# Altium schematic sheet BQ25703A_Module.SchDoc(BQ25703A_Module)
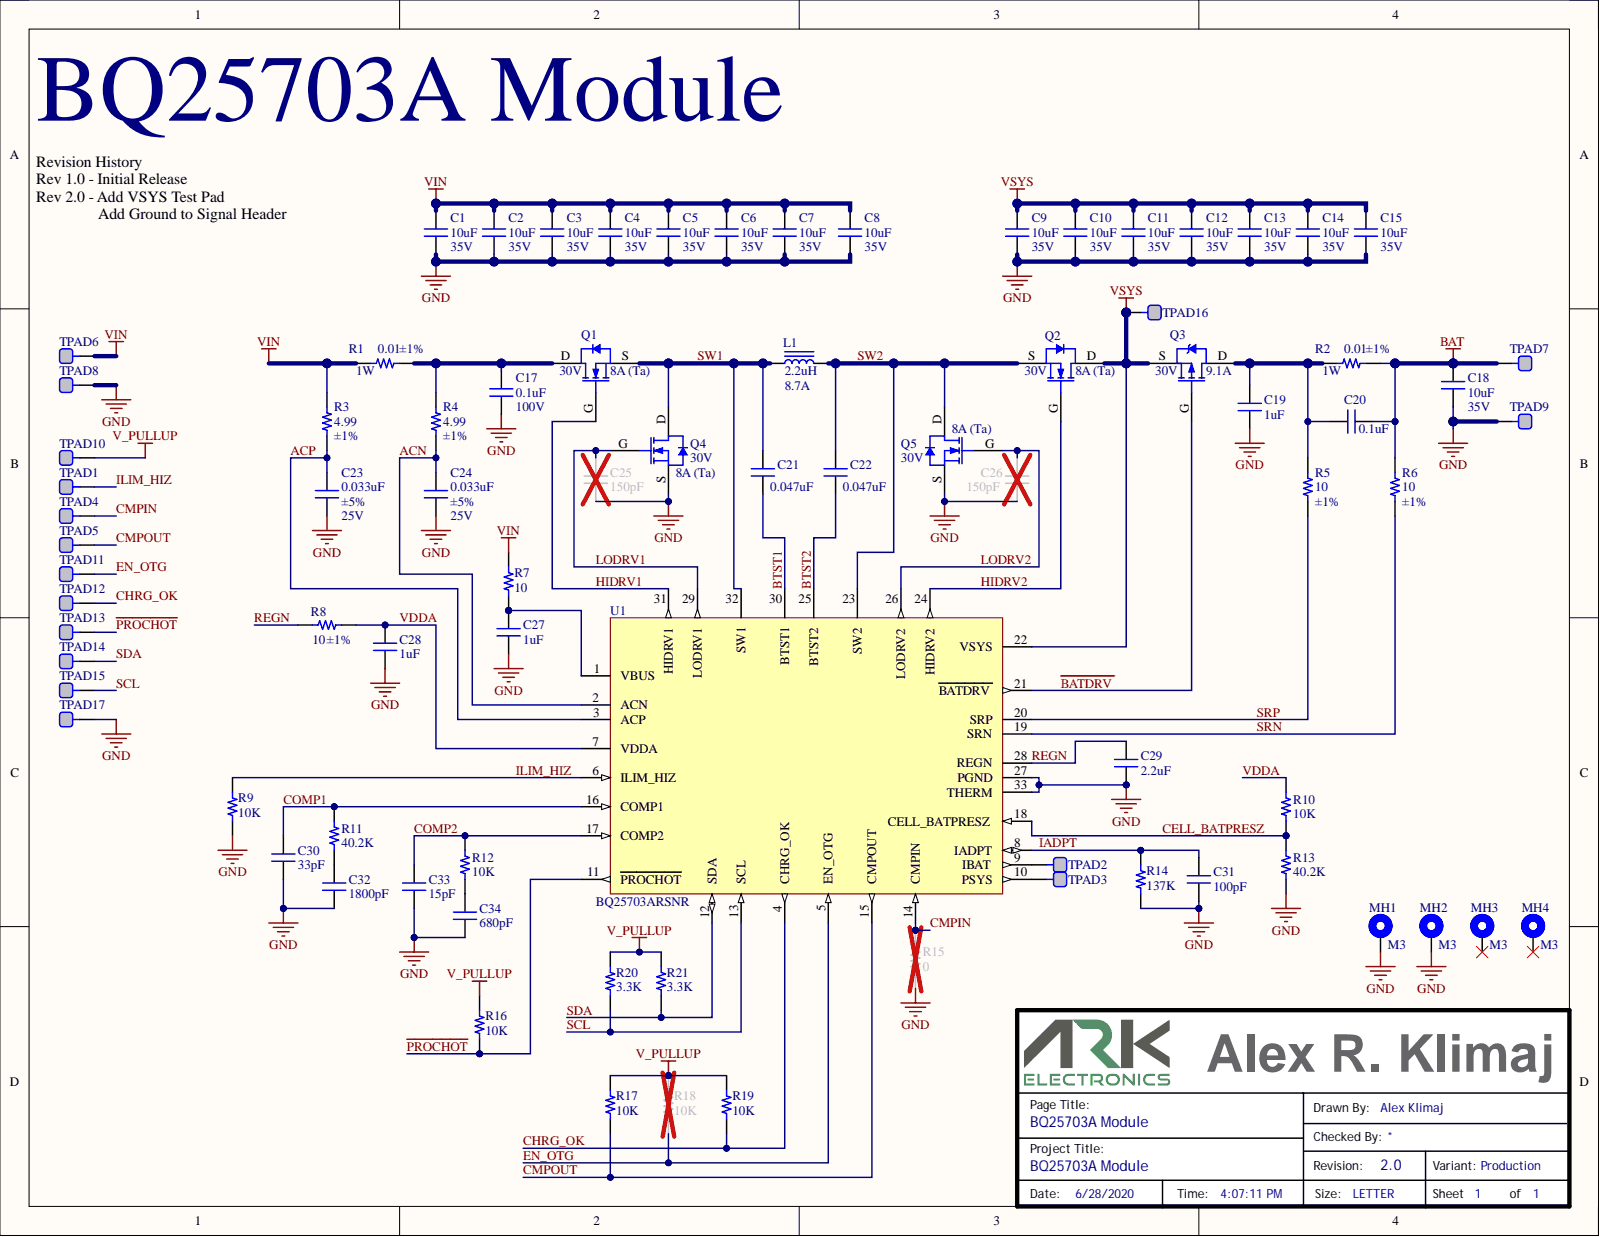
[diagram p0: BQ25703A_Module.SchDoc]

## Parts
C1  10uF  Voltage_Rating: 35V  [CAPC0805(2012)155_N]
C2  10uF  Voltage_Rating: 35V  [CAPC0805(2012)155_N]
C3  10uF  Voltage_Rating: 35V  [CAPC0805(2012)155_N]
C4  10uF  Voltage_Rating: 35V  [CAPC0805(2012)155_N]
C5  10uF  Voltage_Rating: 35V  [CAPC0805(2012)155_N]
C6  10uF  Voltage_Rating: 35V  [CAPC0805(2012)155_N]
C7  10uF  Voltage_Rating: 35V  [CAPC0805(2012)155_N]
C8  10uF  Voltage_Rating: 35V  [CAPC0805(2012)155_N]
C9  10uF  Voltage_Rating: 35V  [CAPC0805(2012)155_N]
C10  10uF  Voltage_Rating: 35V  [CAPC0805(2012)155_N]
C11  10uF  Voltage_Rating: 35V  [CAPC0805(2012)155_N]
C12  10uF  Voltage_Rating: 35V  [CAPC0805(2012)155_N]
C13  10uF  Voltage_Rating: 35V  [CAPC0805(2012)155_N]
C14  10uF  Voltage_Rating: 35V  [CAPC0805(2012)155_N]
C15  10uF  Voltage_Rating: 35V  [CAPC0805(2012)155_N]
C17  0.1uF  Voltage_Rating: 100V  [CAPC0805(2012)155_N]
C18  10uF  Voltage_Rating: 35V  [CAPC0805(2012)155_N]
C19  1uF  [CAPC0805(2012)155_N]
C20  0.1uF  [CAPC0805(2012)155_N]
C21  0.047uF  [CAPC0805(2012)155_N]
C22  0.047uF  [CAPC0805(2012)155_N]
C23  0.033uF  Tolerance: ±5%  Voltage_Rating: 25V  [CAPC0805(2012)155_N]
C24  0.033uF  Tolerance: ±5%  Voltage_Rating: 25V  [CAPC0805(2012)155_N]
C25  150pF  [CAPC0805(2012)155_N]
C26  150pF  [CAPC0805(2012)155_N]
C27  1uF  [CAPC0805(2012)155_N]
C28  1uF  [CAPC0805(2012)155_N]
C29  2.2uF  [CAPC0805(2012)155_N]
C30  33pF  [CAPC0805(2012)155_N]
C31  100pF  [CAPC0805(2012)155_N]
C32  1800pF  [CAPC0805(2012)155_N]
C33  15pF  [CAPC0805(2012)155_N]
C34  680pF  [CAPC0805(2012)155_N]
L1  2.2uH  Current_Rating: 8.7A  [DD1217AS-H-2R2N=P3]
MH1  M3  [Mounting Hole M3]
MH2  M3  [Mounting Hole M3]
MH3  M3  [Mounting Hole M3]
MH4  M3  [Mounting Hole M3]
Q1  Current_Rating: 8A (Ta)  Voltage_Rating: 30V  [RQ3E080BNTB]  (hidden comment: RQ3E080BNTB)
Q2  Current_Rating: 8A (Ta)  Voltage_Rating: 30V  [RQ3E080BNTB]  (hidden comment: RQ3E080BNTB)
Q3  Current_Rating: 9.1A  Voltage_Rating: 30V  [SOP-8 PMOS Micro Commercial]  (hidden comment: MCQ4435-TP)
Q4  Current_Rating: 8A (Ta)  Voltage_Rating: 30V  [RQ3E080BNTB]  (hidden comment: RQ3E080BNTB)
Q5  Current_Rating: 8A (Ta)  Voltage_Rating: 30V  [RQ3E080BNTB]  (hidden comment: RQ3E080BNTB)
R1  0.01  Power_Rating: 1W  [RES1206]
R2  0.01  Power_Rating: 1W  [RES1206]
R3  4.99  Tolerance: ±1%  [RESC0805(2012)_L]
R4  4.99  Tolerance: ±1%  [RESC0805(2012)_L]
R5  10  Tolerance: ±1%  [RESC0805(2012)_L]
R6  10  Tolerance: ±1%  [RESC0805(2012)_L]
R7  10  [RESC0805(2012)_L]
R8  10  Tolerance: ±1%  [RESC0805(2012)_L]
R9  10K  [RESC0805(2012)_L]
R10  10K  [RESC0805(2012)_L]
R11  40.2K  [RESC0805(2012)_L]
R12  10K  [RESC0805(2012)_L]
R13  40.2K  [RESC0805(2012)_L]
R14  137K  [RESC0805(2012)_L]
R15  0  [RESC0805(2012)_L]
R16  10K  [RESC0805(2012)_L]
R17  10K  [RESC0805(2012)_L]
R18  10K  [RESC0805(2012)_L]
R19  10K  [RESC0805(2012)_L]
R20  3.3K  [RESC0805(2012)_L]
R21  3.3K  [RESC0805(2012)_L]
TPAD1  TEST_PAD  [TEST_POINT]  (value not drawn)  (no BOM)
TPAD2  TEST_PAD  [TEST_POINT]  (value not drawn)  (no BOM)
TPAD3  TEST_PAD  [TEST_POINT]  (value not drawn)  (no BOM)
TPAD4  TEST_PAD  [TEST_POINT]  (value not drawn)  (no BOM)
TPAD5  TEST_PAD  [TEST_POINT]  (value not drawn)  (no BOM)
TPAD6  TEST_PAD  [TEST_POINT]  (value not drawn)  (no BOM)
TPAD7  TEST_PAD  [TEST_POINT]  (value not drawn)  (no BOM)
TPAD8  TEST_PAD  [TEST_POINT]  (value not drawn)  (no BOM)
TPAD9  TEST_PAD  [TEST_POINT]  (value not drawn)  (no BOM)
TPAD10  TEST_PAD  [TEST_POINT]  (value not drawn)  (no BOM)
TPAD11  TEST_PAD  [TEST_POINT]  (value not drawn)  (no BOM)
TPAD12  TEST_PAD  [TEST_POINT]  (value not drawn)  (no BOM)
TPAD13  TEST_PAD  [TEST_POINT]  (value not drawn)  (no BOM)
TPAD14  TEST_PAD  [TEST_POINT]  (value not drawn)  (no BOM)
TPAD15  TEST_PAD  [TEST_POINT]  (value not drawn)  (no BOM)
TPAD16  TEST_PAD  [TEST_POINT]  (value not drawn)  (no BOM)
TPAD17  TEST_PAD  [TEST_POINT]  (value not drawn)  (no BOM)
U1  BQ25703ARSNR  [QFN40P400X400X80_HS-33N]

## Nets
BAT: C18.2 C20.1 R2.2 R6.2 TPAD7.1
GND: C1.1 C2.1 C3.1 C4.1 C5.1 C6.1 C7.1 C8.1 C9.1 C10.1 C11.1 C12.1 C13.1 C14.1 C15.1 C17.1 C18.1 C19.2 C23.1 C24.1 C25.2 C26.2 C27.1 C28.2 C29.1 C30.1 C31.1 C32.1 C33.1 C34.1 MH1.1 MH2.1 Q4.S Q5.S R9.2 R13.1 R14.1 R15.2 TPAD8.1 TPAD9.1 TPAD17.1 U1.27(PGND) U1.33(THERM)
V_PULLUP: R16.2 R17.2 R18.2 R19.2 R20.2 R21.2 TPAD10.1
VIN: C1.2 C2.2 C3.2 C4.2 C5.2 C6.2 C7.2 C8.2 R1.1 R3.2 R7.2 TPAD6.1
VSYS: C9.2 C10.2 C11.2 C12.2 C13.2 C14.2 C15.2 Q2.D Q3.S TPAD16.1 U1.22(VSYS)
ACN: C24.2 R4.1 U1.2(ACN)
ACP: C23.2 R3.1 U1.3(ACP)
~{BATDRV}: Q3.G U1.21(BATDRV)
BTST1: C21.1 U1.30(BTST1)
BTST2: C22.1 U1.25(BTST2)
CELL_BATPRESZ: R10.1 R13.2 U1.18(CELL_BATPRESZ)
CHRG_OK: R19.1 TPAD12.1 U1.4(CHRG_OK)
CMPIN: R15.1 TPAD4.1 U1.14(CMPIN)
CMPOUT: R17.1 TPAD5.1 U1.15(CMPOUT)
COMP1: C30.2 R11.2 U1.16(COMP1)
COMP2: C33.2 R12.2 U1.17(COMP2)
EN_OTG: R18.1 TPAD11.1 U1.5(EN_OTG)
HIDRV1: Q1.G U1.31(HIDRV1)
HIDRV2: Q2.G U1.24(HIDRV2)
IADPT: C31.2 R14.2 U1.8(IADPT)
ILIM_HIZ: R9.1 TPAD1.1 U1.6(ILIM_HIZ)
LODRV1: C25.1 Q4.G U1.29(LODRV1)
LODRV2: C26.1 Q5.G U1.26(LODRV2)
~{PROCHOT}: R16.1 TPAD13.1 U1.11(PROCHOT)
REGN: C29.2 R8.2 U1.28(REGN)
SCL: R20.1 TPAD15.1 U1.13(SCL)
SDA: R21.1 TPAD14.1 U1.12(SDA)
SRN: R6.1 U1.19(SRN)
SRP: R5.1 U1.20(SRP)
SW1: C21.2 L1.1 Q1.S Q4.D U1.32(SW1)
SW2: C22.2 L1.2 Q2.S Q5.D U1.23(SW2)
VDDA: C28.1 R8.1 R10.2 U1.7(VDDA)
NetC17_2: C17.2 Q1.D R1.2 R4.2
NetC19_1: C19.1 C20.2 Q3.D R2.1 R5.2
NetC27_2: C27.2 R7.1 U1.1(VBUS)
NetC32_2: C32.2 R11.1
NetC34_2: C34.2 R12.1
NetTPAD2_1: TPAD2.1 U1.9(IBAT)
NetTPAD3_1: TPAD3.1 U1.10(PSYS)

Not connected: MH3 1; MH4 1

## Library data of the parts
C1-C15 C18 = 30220: SMT Capacitor; Manufacturer: TDK Corporation; Mfr Part Description: CAP CER 10uF ±20% 35V X5R 0805 (2012 Metric); Mfr Part Number: C2012X5R1V106M085AC; Database Table Name: Parts; Dielectric: X5R; Tolerance: ±20%; Updated By: aklimaj; Component Kind: Standard; ComponentLink1Description: Datasheet; ComponentLink1URL: https://product.tdk.com/info/en/catalog/datasheets/mlcc_commercial_general_en.pdf; ComponentLink2Description: Supplier Part Details; ComponentLink2URL: https://www.digikey.com/product-detail/en/tdk-corporation/C2012X5R1V106M085AC/445-14419-1-ND/3956085; Created_Date: 2018/10/14 19:21:49; ID: 30220; Library Name: Parts.DbLib; LifeCycle: New; Package_Case: 0805 (2012 Metric); Part_Type: Capacitor; RoHS: Yes; Supplier: Digi-Key; Supplier Part Number: 445-14419-1-ND; Temperature Range: -55C to +85C; Updated_Date: 2018/10/19 21:37:43; Value: 10uF; Created By: aklimaj
C17 = 30221: SMT Capacitor; Manufacturer: Samsung Electro-Mechanics; Mfr Part Description: CAP CER 0.1uF ±10% 100V X7R 0805 (2012 Metric); Mfr Part Number: CL21B104KCFNNNE; Database Table Name: Parts; Dielectric: X7R; Tolerance: ±10%; Updated By: aklimaj; Component Kind: Standard; ComponentLink1Description: Datasheet; ComponentLink1URL: http://www.samsungsem.com/kr/support/product-search/mlcc/CL21B104KCFNNNE.jsp; ComponentLink2Description: Supplier Part Details; ComponentLink2URL: https://www.digikey.com/product-detail/en/samsung-electro-mechanics/CL21B104KCFNNNE/1276-6840-1-ND/5961699; Created_Date: 2018/10/14 19:28:20; ID: 30221; Library Name: Parts.DbLib; LifeCycle: New; Package_Case: 0805 (2012 Metric); Part_Type: Capacitor; RoHS: Yes; Supplier: Digi-Key; Supplier Part Number: 1276-6840-1-ND; Temperature Range: -55C to +125C; Updated_Date: 2018/10/19 21:37:43; Value: 0.1uF; Created By: aklimaj
C19 C27 C28 = 30222: SMT Capacitor; Manufacturer: Samsung Electro-Mechanics; Mfr Part Description: CAP CER 1uF ±10% 50V X7R 0805 (2012 Metric); Mfr Part Number: CL21B105KBFNNNG; Database Table Name: Parts; Dielectric: X7R; Tolerance: ±10%; Updated By: aklimaj; Voltage_Rating: 50V; Component Kind: Standard; ComponentLink1Description: Datasheet; ComponentLink1URL: http://www.samsungsem.com/kr/support/product-search/mlcc/CL21B105KBFNNNG.jsp; ComponentLink2Description: Supplier Part Details; ComponentLink2URL: https://www.digikey.com/product-detail/en/samsung-electro-mechanics/CL21B105KBFNNNG/1276-6470-1-ND/5958098; Created_Date: 2018/10/14 19:30:56; ID: 30222; Library Name: Parts.DbLib; LifeCycle: New; Package_Case: 0805 (2012 Metric); Part_Type: Capacitor; RoHS: Yes; Supplier: Digi-Key; Supplier Part Number: 1276-6470-1-ND; Temperature Range: -55C to +125C; Updated_Date: 2018/10/19 21:37:42; Value: 1uF; Created By: aklimaj
C20 = 30221: SMT Capacitor; Manufacturer: Samsung Electro-Mechanics; Mfr Part Description: CAP CER 0.1uF ±10% 100V X7R 0805 (2012 Metric); Mfr Part Number: CL21B104KCFNNNE; Database Table Name: Parts; Dielectric: X7R; Tolerance: ±10%; Updated By: aklimaj; Voltage_Rating: 100V; Component Kind: Standard; ComponentLink1Description: Datasheet; ComponentLink1URL: http://www.samsungsem.com/kr/support/product-search/mlcc/CL21B104KCFNNNE.jsp; ComponentLink2Description: Supplier Part Details; ComponentLink2URL: https://www.digikey.com/product-detail/en/samsung-electro-mechanics/CL21B104KCFNNNE/1276-6840-1-ND/5961699; Created_Date: 2018/10/14 19:28:20; ID: 30221; Library Name: Parts.DbLib; LifeCycle: New; Package_Case: 0805 (2012 Metric); Part_Type: Capacitor; RoHS: Yes; Supplier: Digi-Key; Supplier Part Number: 1276-6840-1-ND; Temperature Range: -55C to +125C; Updated_Date: 2018/10/19 21:37:43; Value: 0.1uF; Created By: aklimaj
C21 C22 = 30253: SMT Capacitor; Manufacturer: Walsin Technology Corporation; Mfr Part Description: CAP CER 0.047uF ±5% 50V X7R 0805 (2012 Metric); Mfr Part Number: 0805B473J500CT; Database Table Name: Parts; Dielectric: X7R; Tolerance: ±5%; Updated By: aklimaj; Voltage_Rating: 50V; Component Kind: Standard; ComponentLink1Description: Datasheet; ComponentLink1URL: http://www.passivecomponent.com/indexsea/ASC_General_Purpose.pdf; ComponentLink2Description: Supplier Part Details; ComponentLink2URL: https://www.digikey.com/product-detail/en/walsin-technology-corporation/0805B473J500CT/1292-1583-1-ND/9355587; Created_Date: 2018/11/04 21:59:57; ID: 30253; Library Name: Parts.DbLib; LifeCycle: New; Package_Case: 0805 (2012 Metric); Part_Type: Capacitor; RoHS: Yes; Supplier: Digi-Key; Supplier Part Number: 1292-1583-1-ND; Temperature Range: -55C to +125C; Updated_Date: 2018/11/04 22:00:12; Value: 0.047uF; Created By: aklimaj
C23 C24 = 30250: SMT Capacitor; Manufacturer: KEMET; Mfr Part Description: CAP CER 0.033uF ±5% 25V X7R 0805 (2012 Metric); Mfr Part Number: C0805C333J3RACTU; Database Table Name: Parts; Dielectric: X7R; Updated By: aklimaj; Component Kind: Standard; ComponentLink1Description: Datasheet; ComponentLink1URL: https://api.kemet.com/component-edge/download/datasheet/C0805C333J3RACTU.pdf; ComponentLink2Description: Supplier Part Details; ComponentLink2URL: https://www.digikey.com/product-detail/en/kemet/C0805C333J3RACTU/399-8069-1-ND/3471792; Created_Date: 2018/11/04 16:40:30; ID: 30250; Library Name: Parts.DbLib; LifeCycle: New; Package_Case: 0805 (2012 Metric); Part_Type: Capacitor; RoHS: Yes; Supplier: Digi-Key; Supplier Part Number: 399-8069-1-ND; Temperature Range: -55C to +125C; Updated_Date: 2018/11/04 16:40:46; Value: 0.033uF; Created By: aklimaj
C25 C26 = 30254: SMT Capacitor; Manufacturer: Samsung Electro-Mechanics; Mfr Part Description: CAP CER 150pF ±10% 50V X7R 0805 (2012 Metric); Mfr Part Number: CL21B151KBANNNC; Database Table Name: Parts; Dielectric: X7R; Tolerance: ±10%; Updated By: aklimaj; Voltage_Rating: 50V; Component Kind: Standard; ComponentLink1Description: Datasheet; ComponentLink1URL: http://www.samsungsem.com/kr/support/product-search/mlcc/CL21B151KBANNNC.jsp; ComponentLink2Description: Supplier Part Details; ComponentLink2URL: https://www.digikey.com/product-detail/en/samsung-electro-mechanics/CL21B151KBANNNC/1276-2457-1-ND/3890543; Created_Date: 2018/11/04 22:15:55; ID: 30254; Library Name: Parts.DbLib; LifeCycle: New; Package_Case: 0805 (2012 Metric); Part_Type: Capacitor; RoHS: Yes; Supplier: Digi-Key; Supplier Part Number: 1276-2457-1-ND; Temperature Range: -55C to +125C; Updated_Date: 2018/11/04 22:16:19; Value: 150pF; Created By: aklimaj
C29 = 30257: SMT Capacitor; Manufacturer: Samsung Electro-Mechanics; Mfr Part Description: CAP CER 2.2uF ±10% 25V X5R 0805 (2012 Metric); Mfr Part Number: CL21A225KAFNNNG; Database Table Name: Parts; Dielectric: X5R; Tolerance: ±10%; Updated By: aklimaj; Voltage_Rating: 25V; Component Kind: Standard; ComponentLink1Description: Datasheet; ComponentLink1URL: http://www.samsungsem.com/kr/support/product-search/mlcc/CL21A225KAFNNNG.jsp; ComponentLink2Description: Supplier Part Details; ComponentLink2URL: https://www.digikey.com/product-detail/en/samsung-electro-mechanics/CL21A225KAFNNNG/1276-6458-1-ND/5958086; Created_Date: 2018/11/07 15:12:27; ID: 30257; Library Name: Parts.DbLib; LifeCycle: New; Package_Case: 0805 (2012 Metric); Part_Type: Capacitor; RoHS: Yes; Supplier: Digi-Key; Supplier Part Number: 1276-6458-1-ND; Temperature Range: -55C to +85C; Updated_Date: 2018/11/07 15:12:41; Value: 2.2uF; Created By: aklimaj
C30 = 30258: SMT Capacitor; Manufacturer: Samsung Electro-Mechanics; Mfr Part Description: CAP CER 33pF ±5% 50V NPO 0805 (2012 Metric); Mfr Part Number: CL21C330JBANNNC; Database Table Name: Parts; Dielectric: NPO; Tolerance: ±5%; Updated By: aklimaj; Voltage_Rating: 50V; Component Kind: Standard; ComponentLink1Description: Datasheet; ComponentLink1URL: http://www.samsungsem.com/kr/support/product-search/mlcc/CL21C330JBANNNC.jsp; ComponentLink2Description: Supplier Part Details; ComponentLink2URL: https://www.digikey.com/product-detail/en/samsung-electro-mechanics/CL21C330JBANNNC/1276-1105-1-ND/3889191; Created_Date: 2018/11/07 18:07:31; ID: 30258; Library Name: Parts.DbLib; LifeCycle: New; Package_Case: 0805 (2012 Metric); Part_Type: Capacitor; RoHS: Yes; Supplier: Digi-Key; Supplier Part Number: 1276-1105-1-ND; Temperature Range: -55C to +125C; Updated_Date: 2018/11/07 18:07:45; Value: 33pF; Created By: aklimaj
C31 = 30264: SMT Capacitor; Manufacturer: Samsung Electro-Mechanics; Mfr Part Description: CAP CER 100pF ±5% 100V NPO 0805 (2012 Metric); Mfr Part Number: CL21C101JC61PNC; Database Table Name: Parts; Dielectric: NPO; Tolerance: ±5%; Updated By: aklimaj; Voltage_Rating: 100V; Component Kind: Standard; ComponentLink1Description: Datasheet; ComponentLink1URL: http://www.samsungsem.com/kr/support/product-search/mlcc/CL21C101JC61PNC.jsp; ComponentLink2Description: Supplier Part Details; ComponentLink2URL: https://www.digikey.com/product-detail/en/samsung-electro-mechanics/CL21C101JC61PNC/1276-2569-1-ND/3890655; Created_Date: 2018/11/07 18:38:28; ID: 30264; Library Name: Parts.DbLib; LifeCycle: New; Package_Case: 0805 (2012 Metric); Part_Type: Capacitor; RoHS: Yes; Supplier: Digi-Key; Supplier Part Number: 1276-2569-1-ND; Temperature Range: -55C to +125C; Updated_Date: 2018/11/07 18:38:44; Value: 100pF; Created By: aklimaj
C32 = 30259: SMT Capacitor; Manufacturer: Samsung Electro-Mechanics; Mfr Part Description: CAP CER 1800pF ±10% 50V X7R 0805 (2012 Metric); Mfr Part Number: CL21B182KBANNNC; Database Table Name: Parts; Dielectric: X7R; Tolerance: ±10%; Updated By: aklimaj; Voltage_Rating: 50V; Component Kind: Standard; ComponentLink1Description: Datasheet; ComponentLink1URL: http://www.samsungsem.com/kr/support/product-search/mlcc/CL21B182KBANNNC.jsp; ComponentLink2Description: Supplier Part Details; ComponentLink2URL: https://www.digikey.com/product-detail/en/samsung-electro-mechanics/CL21B182KBANNNC/1276-2465-1-ND/3890551; Created_Date: 2018/11/07 18:09:13; ID: 30259; Library Name: Parts.DbLib; LifeCycle: New; Package_Case: 0805 (2012 Metric); Part_Type: Capacitor; RoHS: Yes; Supplier: Digi-Key; Supplier Part Number: 1276-2465-1-ND; Temperature Range: -55C to +125C; Updated_Date: 2018/11/07 18:09:28; Value: 1800pF; Created By: aklimaj
C33 = 30262: SMT Capacitor; Manufacturer: Wurth Electronics Inc.; Mfr Part Description: CAP CER 15pF ±5% 25V NPO 0805 (2012 Metric); Mfr Part Number: 885012007029; Database Table Name: Parts; Dielectric: NPO; Tolerance: ±5%; Updated By: aklimaj; Voltage_Rating: 25V; Component Kind: Standard; ComponentLink1Description: Datasheet; ComponentLink1URL: https://katalog.we-online.de/pbs/datasheet/885012007029.pdf; ComponentLink2Description: Supplier Part Details; ComponentLink2URL: https://www.digikey.com/product-detail/en/wurth-electronics-inc/885012007029/732-7828-1-ND/5454455; Created_Date: 2018/11/07 18:22:56; ID: 30262; Library Name: Parts.DbLib; LifeCycle: New; Package_Case: 0805 (2012 Metric); Part_Type: Capacitor; RoHS: Yes; Supplier: Digi-Key; Supplier Part Number: 732-7828-1-ND; Temperature Range: -55C to +125C; Updated_Date: 2018/11/07 18:23:10; Value: 15pF; Created By: aklimaj
C34 = 30261: SMT Capacitor; Manufacturer: Samsung Electro-Mechanics; Mfr Part Description: CAP CER 680pF ±10% 50V X7R 0805 (2012 Metric); Mfr Part Number: CL21B681KBANNNC; Database Table Name: Parts; Dielectric: X7R; Tolerance: ±10%; Updated By: aklimaj; Voltage_Rating: 50V; Component Kind: Standard; ComponentLink1Description: Datasheet; ComponentLink1URL: http://www.samsungsem.com/kr/support/product-search/mlcc/CL21B681KBANNNC.jsp; ComponentLink2Description: Supplier Part Details; ComponentLink2URL: https://www.digikey.com/product-detail/en/samsung-electro-mechanics/CL21B681KBANNNC/1276-2538-1-ND/3890624; Created_Date: 2018/11/07 18:21:13; ID: 30261; Library Name: Parts.DbLib; LifeCycle: New; Package_Case: 0805 (2012 Metric); Part_Type: Capacitor; RoHS: Yes; Supplier: Digi-Key; Supplier Part Number: 1276-2538-1-ND; Temperature Range: -55C to +125C; Updated_Date: 2018/11/07 18:21:25; Value: 680pF; Created By: aklimaj
L1 = 30252: SMT Inductor; Manufacturer: Murata Electronics North America; Mfr Part Description: INDUCTOR 2.2uH ±30% 8.7A Nonstandard; Database Table Name: Parts; Tolerance: ±30%; Updated By: aklimaj; Component Kind: Standard; ComponentLink1Description: Datasheet; ComponentLink1URL: http://search.murata.co.jp/Ceramy/image/img/P02/J(E)TE243B-0036_DEM8045C(DD)_reference.pdf; ComponentLink2Description: Supplier Part Details; ComponentLink2URL: https://www.digikey.com/product-detail/en/murata-electronics-north-america/DD1217AS-H-2R2N=P3/490-13196-1-ND/5812908; Created_Date: 2018/11/04 21:43:14; ID: 30252; Library Name: Parts.DbLib; LifeCycle: New; Mfr Part Number: DD1217AS-H-2R2N=P3; Package_Case: Nonstandard; Part_Type: Inductor; RoHS: Yes; Supplier: Digi-Key; Supplier Part Number: 490-13196-1-ND; Updated_Date: 2018/11/04 21:56:42; Value: 2.2uH; Created By: aklimaj
MH1-MH4 = 30267: Database Table Name: Parts; Updated By: aklimaj; Component Kind: Standard; ComponentLink1Description: Datasheet; ComponentLink2Description: Supplier Part Details; Created_Date: 2018/11/12 18:30:41; ID: 30267; Library Name: Parts.DbLib; LifeCycle: New; Mfr Part Description: Mounting Hole M3; RoHS: Yes; Updated_Date: 2018/11/12 18:36:29; Value: M3; Created By: aklimaj
Q1 Q2 Q4 Q5 = 30251: Manufacturer: Rohm Semiconductor; Mfr Part Description: MOSFET N-CH 30V 8A HSMT8; Part_Type: Discrete; Database Table Name: Parts; Updated By: aklimaj; Component Kind: Standard; ComponentLink1Description: Datasheet; ComponentLink1URL: https://www.rohm.com/datasheet/RQ3E080BN/rq3e080bn-e; ComponentLink2Description: Supplier Part Details; ComponentLink2URL: https://www.digikey.com/product-detail/en/rohm-semiconductor/RQ3E080BNTB/RQ3E080BNTBCT-ND/5042543; Created_Date: 2018/11/04 17:08:16; ID: 30251; Library Name: Parts.DbLib; LifeCycle: New; Mfr Part Number: RQ3E080BNTB; Package_Case: 8-PowerVDFN; RoHS: Yes; Supplier: Digi-Key; Supplier Part Number: RQ3E080BNTBCT-ND; Temperature Range: 150C (TJ); Updated_Date: 2018/11/04 17:31:45; Value: RQ3E080BNTB; Created By: aklimaj
Q3 = 30255: Manufacturer: Micro Commercial Co; Mfr Part Description: P-CHANNEL MOSFET, SOP-8 PACKAGE; Part_Type: Discrete; Database Table Name: Parts; Updated By: aklimaj; Component Kind: Standard; ComponentLink1Description: Datasheet; ComponentLink1URL: http://www.mccsemi.com/up_pdf/MCQ4435(SOP-8)-B.pdf; ComponentLink2Description: Supplier Part Details; ComponentLink2URL: https://www.digikey.com/product-detail/en/micro-commercial-co/MCQ4435-TP/MCQ4435-TPMSCT-ND/6616138; Created_Date: 2018/11/05 12:00:21; ID: 30255; Library Name: Parts.DbLib; LifeCycle: New; Mfr Part Number: MCQ4435-TP; Package_Case: 8-SOIC (0.154", 3.90mm Width); RoHS: Yes; Supplier: Digi-Key; Supplier Part Number: MCQ4435-TPMSCT-ND; Temperature Range: -55C to +150C (TJ); Updated_Date: 2018/11/06 10:10:44; Value: MCQ4435-TP; Created By: aklimaj
R1 R2 = 30248: SMT Resistor; Manufacturer: Ohmite; Mfr Part Description: RES 0.01 Ohm ±1% 1W 1206 (3216 Metric); Mfr Part Number: LVT12R0100FER; Database Table Name: Parts; Temperature Coeff: ±75ppm/degC; Tolerance: ±1%; Updated By: aklimaj; Component Kind: Standard; ComponentLink1Description: Datasheet; ComponentLink1URL: https://www.ohmite.com/assets/docs/res_lvt.pdf?r=false; ComponentLink2Description: Supplier Part Details; ComponentLink2URL: https://www.digikey.com/product-detail/en/ohmite/LVT12R0100FER/LVT12R0100FERCT-ND/9556182; Created_Date: 2018/11/04 16:28:38; ID: 30248; Library Name: Parts.DbLib; LifeCycle: New; Package_Case: 1206 (3216 Metric); Part_Type: Resistor; RoHS: Yes; Supplier: Digi-Key; Supplier Part Number: LVT12R0100FERCT-ND; Temperature Range: -55C to +155C; Updated_Date: 2018/11/07 17:03:59; Value: 0.01; Created By: aklimaj
R3 R4 = 30249: SMT Resistor; Manufacturer: Stackpole Electronics Inc.; Mfr Part Description: RES 4.99 Ohm ±1% 1/8W 0805 (2012 Metric); Mfr Part Number: RMCF0805FT4R99; Database Table Name: Parts; Power_Rating: 0.125W, 1/8W; Temperature Coeff: ±200ppm/degC; Updated By: aklimaj; Component Kind: Standard; ComponentLink1Description: Datasheet; ComponentLink1URL: https://www.seielect.com/Catalog/SEI-RMCF_RMCP.pdf; ComponentLink2Description: Supplier Part Details; ComponentLink2URL: https://www.digikey.com/product-detail/en/stackpole-electronics-inc/RMCF0805FT4R99/RMCF0805FT4R99CT-ND/2418423; Created_Date: 2018/11/04 16:35:28; ID: 30249; Library Name: Parts.DbLib; LifeCycle: New; Package_Case: 0805 (2012 Metric); Part_Type: Resistor; RoHS: Yes; Supplier: Digi-Key; Supplier Part Number: RMCF0805FT4R99CT-ND; Temperature Range: -55C to +155C; Updated_Date: 2018/11/04 16:35:46; Value: 4.99; Created By: aklimaj
R5 R6 R8 = 30256: SMT Resistor; Manufacturer: Stackpole Electronics Inc.; Mfr Part Description: RES 10 Ohm ±1% 1/8W 0805 (2012 Metric); Mfr Part Number: RMCF0805FT10R0; Database Table Name: Parts; Power_Rating: 0.125W, 1/8W; Temperature Coeff: ±100ppm/degC; Updated By: aklimaj; Component Kind: Standard; ComponentLink1Description: Datasheet; ComponentLink1URL: https://www.seielect.com/Catalog/SEI-RMCF_RMCP.pdf; ComponentLink2Description: Supplier Part Details; ComponentLink2URL: https://www.digikey.com/product-detail/en/stackpole-electronics-inc/RMCF0805FT10R0/RMCF0805FT10R0CT-ND/1942314; Created_Date: 2018/11/07 14:51:36; ID: 30256; Library Name: Parts.DbLib; LifeCycle: New; Package_Case: 0805 (2012 Metric); Part_Type: Resistor; RoHS: Yes; Supplier: Digi-Key; Supplier Part Number: RMCF0805FT10R0CT-ND; Temperature Range: -55C to +155C; Updated_Date: 2018/11/07 14:51:54; Value: 10; Created By: aklimaj
R7 = 30256: SMT Resistor; Manufacturer: Stackpole Electronics Inc.; Mfr Part Description: RES 10 Ohm ±1% 1/8W 0805 (2012 Metric); Mfr Part Number: RMCF0805FT10R0; Database Table Name: Parts; Power_Rating: 0.125W, 1/8W; Temperature Coeff: ±100ppm/degC; Tolerance: ±1%; Updated By: aklimaj; Component Kind: Standard; ComponentLink1Description: Datasheet; ComponentLink1URL: https://www.seielect.com/Catalog/SEI-RMCF_RMCP.pdf; ComponentLink2Description: Supplier Part Details; ComponentLink2URL: https://www.digikey.com/product-detail/en/stackpole-electronics-inc/RMCF0805FT10R0/RMCF0805FT10R0CT-ND/1942314; Created_Date: 2018/11/07 14:51:36; ID: 30256; Library Name: Parts.DbLib; LifeCycle: New; Package_Case: 0805 (2012 Metric); Part_Type: Resistor; RoHS: Yes; Supplier: Digi-Key; Supplier Part Number: RMCF0805FT10R0CT-ND; Temperature Range: -55C to +155C; Updated_Date: 2018/11/07 14:51:54; Value: 10; Created By: aklimaj
R9 R10 R12 R16-R19 = 30227: SMT Resistor; Manufacturer: Bourns Inc.; Mfr Part Description: RES 10K Ohm ±1% 1/8W 0805 (2012 Metric); Mfr Part Number: CR0805-FX-1002ELF; Database Table Name: Parts; Power_Rating: 0.125W, 1/8W; Temperature Coeff: ±100ppm/degC; Tolerance: ±1%; Updated By: aklimaj; Component Kind: Standard; ComponentLink1Description: Datasheet; ComponentLink1URL: https://www.bourns.com/docs/Product-Datasheets/CHPREZTR.pdf; ComponentLink2Description: Supplier Part Details; ComponentLink2URL: https://www.digikey.com/product-detail/en/bourns-inc/CR0805-FX-1002ELF/CR0805-FX-1002ELFCT-ND/3592928; Created_Date: 2018/10/16 22:16:46; ID: 30227; Library Name: Parts.DbLib; LifeCycle: New; Package_Case: 0805 (2012 Metric); Part_Type: Resistor; RoHS: Yes; Supplier: Digi-Key; Supplier Part Number: CR0805-FX-1002ELFCT-ND; Temperature Range: -55C to +155C; Updated_Date: 2018/10/19 21:37:37; Value: 10K; Created By: aklimaj
R11 R13 = 30260: SMT Resistor; Manufacturer: Yageo; Mfr Part Description: RES 40.2K Ohm ±1% 1/8W 0805 (2012 Metric); Mfr Part Number: RC0805FR-0740K2L; Database Table Name: Parts; Power_Rating: 0.125W, 1/8W; Temperature Coeff: ±100ppm/degC; Tolerance: ±1%; Updated By: aklimaj; Component Kind: Standard; ComponentLink1Description: Datasheet; ComponentLink1URL: http://www.yageo.com/documents/recent/PYu-RC_Group_51_RoHS_L_9.pdf; ComponentLink2Description: Supplier Part Details; ComponentLink2URL: https://www.digikey.com/product-detail/en/yageo/RC0805FR-0740K2L/311-40.2KCRCT-ND/730888; Created_Date: 2018/11/07 18:10:45; ID: 30260; Library Name: Parts.DbLib; LifeCycle: New; Package_Case: 0805 (2012 Metric); Part_Type: Resistor; RoHS: Yes; Supplier: Digi-Key; Supplier Part Number: 311-40.2KCRCT-ND; Temperature Range: -55C to +155C; Updated_Date: 2018/11/07 18:10:59; Value: 40.2K; Created By: aklimaj
R14 = 30263: SMT Resistor; Manufacturer: Stackpole Electronics Inc.; Mfr Part Description: RES 137K Ohm ±1% 1/8W 0805 (2012 Metric); Mfr Part Number: RMCF0805FT137K; Database Table Name: Parts; Power_Rating: 0.125W, 1/8W; Temperature Coeff: ±100ppm/degC; Tolerance: ±1%; Updated By: aklimaj; Component Kind: Standard; ComponentLink1Description: Datasheet; ComponentLink1URL: https://www.seielect.com/Catalog/SEI-RMCF_RMCP.pdf; ComponentLink2Description: Supplier Part Details; ComponentLink2URL: https://www.digikey.com/product-detail/en/stackpole-electronics-inc/RMCF0805FT137K/RMCF0805FT137KCT-ND/2418254; Created_Date: 2018/11/07 18:36:04; ID: 30263; Library Name: Parts.DbLib; LifeCycle: New; Package_Case: 0805 (2012 Metric); Part_Type: Resistor; RoHS: Yes; Supplier: Digi-Key; Supplier Part Number: RMCF0805FT137KCT-ND; Temperature Range: -55C to +155C; Updated_Date: 2018/11/07 18:36:20; Value: 137K; Created By: aklimaj
R15 = 30238: SMT Resistor; Manufacturer: Bourns Inc.; Mfr Part Description: RES 0 Ohm Jumper 1/8W 0805 (2012 Metric); Mfr Part Number: CR0805-J/-000ELF; Current_Rating: 2A; Database Table Name: Parts; Power_Rating: 0.125W, 1/8W; Tolerance: Jumper; Updated By: aklimaj; Voltage_Rating: 150V; Component Kind: Standard; ComponentLink1Description: Datasheet; ComponentLink1URL: https://www.bourns.com/docs/Product-Datasheets/CHPREZTR.pdf; ComponentLink2Description: Supplier Part Details; ComponentLink2URL: https://www.digikey.com/product-detail/en/bourns-inc/CR0805-J-000ELF/CR0805-J-000ELFCT-ND/3592913; Created_Date: 2018/11/02 22:19:43; ID: 30238; Library Name: Parts.DbLib; LifeCycle: New; Package_Case: 0805 (2012 Metric); Part_Type: Resistor; RoHS: Yes; Supplier: Digi-Key; Supplier Part Number: CR0805-J/-000ELFCT-ND; Temperature Range: -55C to +155C; Updated_Date: 2018/11/02 22:20:59; Value: 0; Created By: aklimaj
R20 R21 = 30235: SMT Resistor; Manufacturer: Stackpole Electronics Inc.; Mfr Part Description: RES 3.3K Ohm ±1% 1/8W 0805 (2012 Metric); Mfr Part Number: RMCF0805FT3K30; Database Table Name: Parts; Power_Rating: 0.125W, 1/8W; Temperature Coeff: ±100ppm/degC; Tolerance: ±1%; Updated By: aklimaj; Component Kind: Standard; ComponentLink1Description: Datasheet; ComponentLink1URL: https://www.seielect.com/Catalog/SEI-RMCF_RMCP.pdf; ComponentLink2Description: Supplier Part Details; ComponentLink2URL: https://www.digikey.com/product-detail/en/stackpole-electronics-inc/RMCF0805FT3K30/RMCF0805FT3K30CT-ND/1942399; Created_Date: 2018/11/02 19:30:31; ID: 30235; Library Name: Parts.DbLib; LifeCycle: New; Package_Case: 0805 (2012 Metric); Part_Type: Resistor; RoHS: Yes; Supplier: Digi-Key; Supplier Part Number: RMCF0805FT3K30CT-ND; Temperature Range: -55C to +155C; Updated_Date: 2018/11/02 19:31:08; Value: 3.3K; Created By: aklimaj
TPAD1-TPAD17 = 30015: Mfr Part Description: Test Point; Database Table Name: Parts; Updated By: aklimaj; ComponentLink1Description: Datasheet; ComponentLink2Description: Supplier Part Details; Created_Date: 2017/07/13 09:26:35; Description: TEST_PAD; ID: 30015; Library Name: Parts.DbLib; LifeCycle: New; Part_Type: Test Point; RoHS: Yes; Updated_Date: 2017/07/13 09:29:11; Created By: aklimaj
U1 = 30225: Manufacturer: Texas Instruments; Part_Type: IC; Database Table Name: Parts; Sub_Type: Power; Updated By: aklimaj; Component Kind: Standard; ComponentLink1Description: Datasheet; ComponentLink1URL: http://www.ti.com/lit/ds/symlink/bq25703a.pdf; ComponentLink2Description: Supplier Part Details; ComponentLink2URL: https://www.digikey.com/product-detail/en/texas-instruments/BQ25703ARSNR/296-47649-1-ND/8133018; Created_Date: 2018/10/14 22:39:52; ID: 30225; Library Name: Parts.DbLib; LifeCycle: New; Mfr Part Description: BQ25703ARSNR; Mfr Part Number: BQ25703ARSNR; Package_Case: 32-WFQFN Exposed Pad; RoHS: Yes; Supplier: Digi-Key; Supplier Part Number: 296-47649-1-ND; Temperature Range: -40C to +85C (TA); Updated_Date: 2018/10/19 21:37:40; Value: BQ25703ARSNR; Created By: aklimaj
